# Altium schematic sheet power.SchDoc
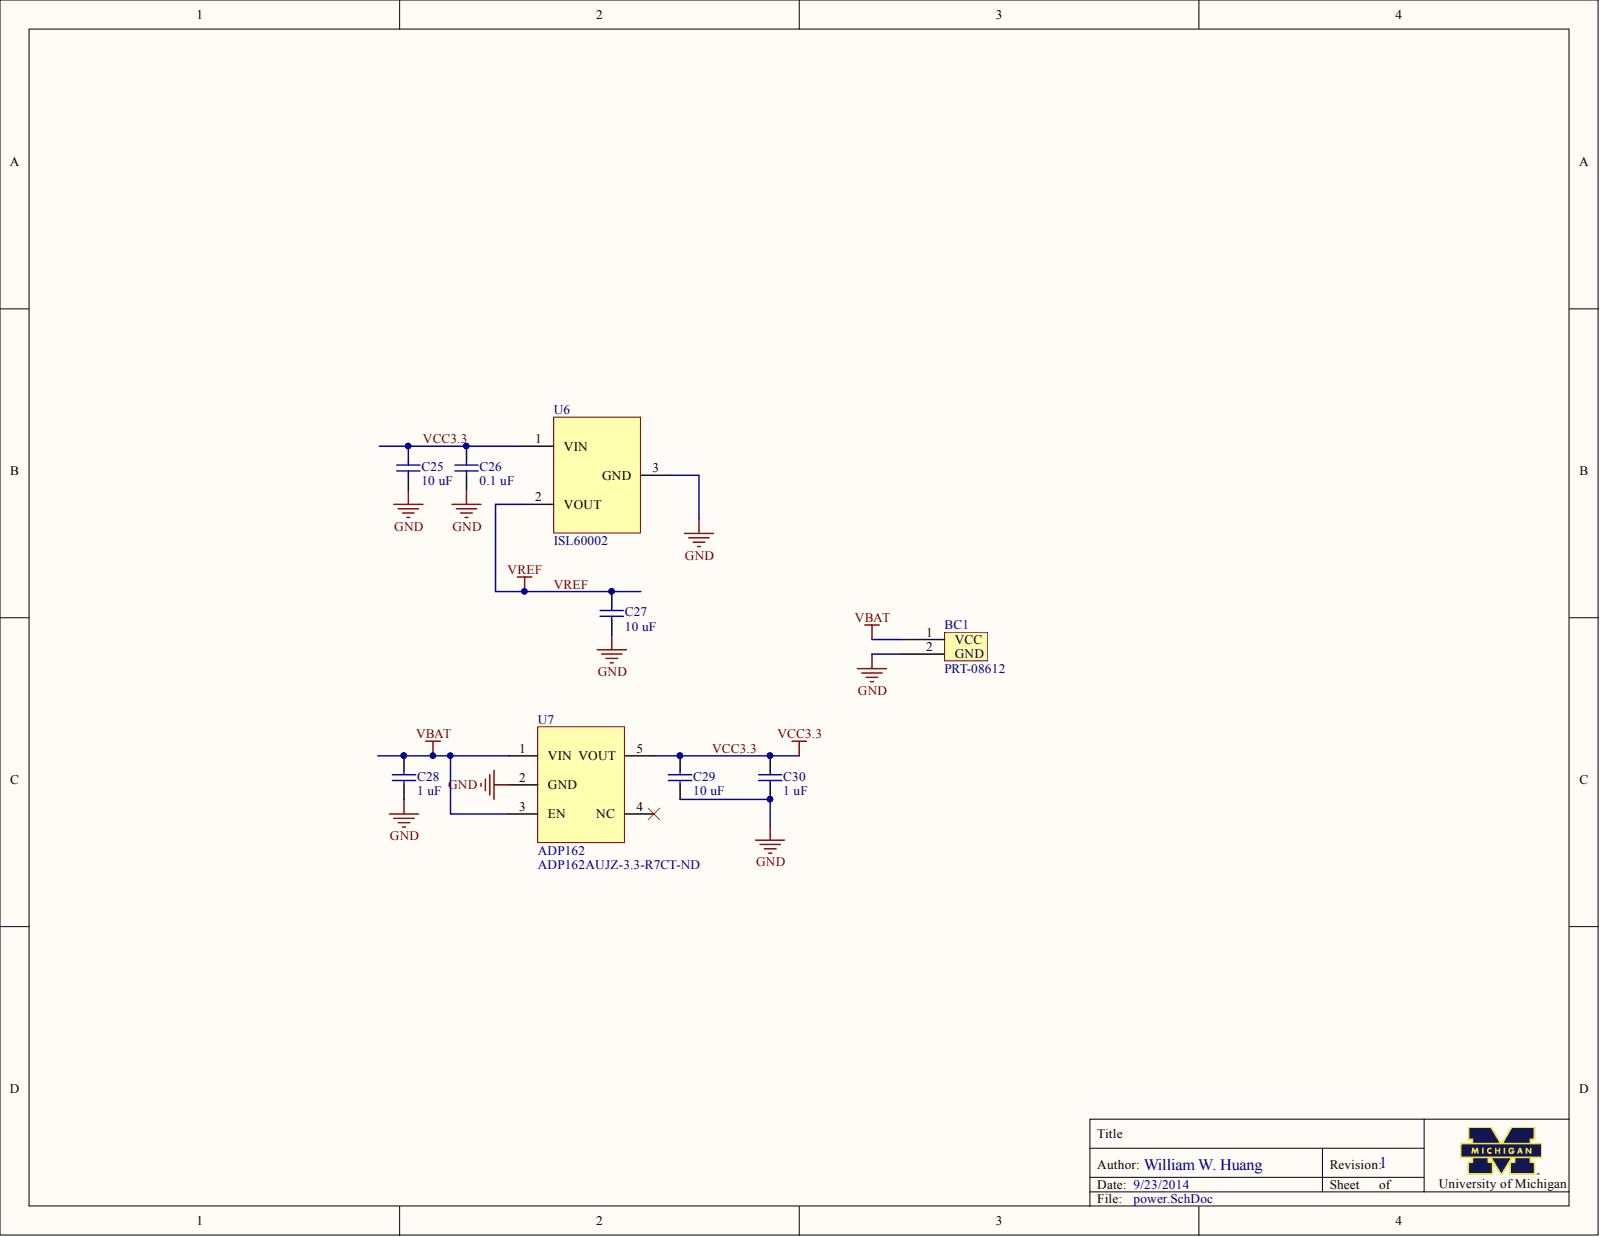
[diagram p0: power.SchDoc]

## Parts
BC1  PRT-08612  [JST PH 2PIN]
C25  value 10 uF  [0603]  (hidden comment: Ceramic capacitor)
C26  value 0.1 uF  [0402]  (hidden comment: Ceramic capacitor)
C27  value 10 uF  [0603]  (hidden comment: Ceramic capacitor)
C28  value 1 uF  [0402]  (hidden comment: Ceramic capacitor)
C29  value 10 uF  [0603]  (hidden comment: Ceramic capacitor)
C30  value 1 uF  [0402]  (hidden comment: Ceramic capacitor)
U6  ISL60002  [SOT23-3N]
U7  ADP162  Supplier Part Number 1: ADP162AUJZ-3.3-R7CT-ND  [TSOT23-5]

## Nets
GND: BC1.2 C25.2 C26.2 C27.2 C28.2 C29.2 C30.2 U6.3 U7.2(GND)
VBAT: BC1.1 C28.1 U7.1(VIN) U7.3(EN)
VCC3.3: C25.1 C26.1 C29.1 C30.1 U6.1 U7.5(VOUT)
VREF: C27.1 U6.2

Not connected: U7 4(NC)

## Library data of the parts
BC1 = JST-PH-2mm-2Pin: 2-pin JST-PH 2mm Battery Connector; Component Kind: Standard; Supplier 1: Sparkfun; Supplier Part Number 1: PRT-08612; Library Name: opo_general.SchLib
C25 C27 C29 = C_10u_0603_ceramic: Ceramic capacitor, 10 uF, 0603 package; Manufacture: TDK; tolerance: 20%; voltage rated: 6.3V; Component Kind: Standard; Library Name: rlc.SchLib; package: 0603; Supplier 1: Digi-Key; Supplier Part Number 1: 445-4112-1-ND
C26 = C_0.1u_0402_ceramic: Ceramic capacitor, 0.1u, 0402 package; Manufacture: TDK; tolerance: 10%; voltage rated: 10 V; Component Kind: Standard; Library Name: rlc.SchLib; package: 0402; Supplier 1: Digi-Key; Supplier Part Number 1: 445-1265-1-ND
C28 C30 = C_1u_0402_ceramic: Ceramic capacitor, 1 uF, 0402 package; Manufacture: TDK; tolerance: 10%; voltage rated: 6.3 V; Component Kind: Standard; Library Name: rlc.SchLib; package: 0402; Supplier 1: Digi-Key; Supplier Part Number 1: 445-5000-1-ND
U6 = ISL60002: IC VREF SERIES PREC SOT-23-3; Component Kind: Standard; Supplier 1: Digi-Key; Supplier Part Number 1: ISL60002DIH310Z-TKCT-ND; Library Name: opo.SchLib
U7 = ADP162: Ultralow Quiescent Current,  150 mA, CMOS Linear Regulators; Component Kind: Standard; Supplier 1: Digi-Key; Library Name: opo.SchLib
